# Altium schematic sheet lv_mcu_ios_100.SchDoc(lv_mcu_ios_100)
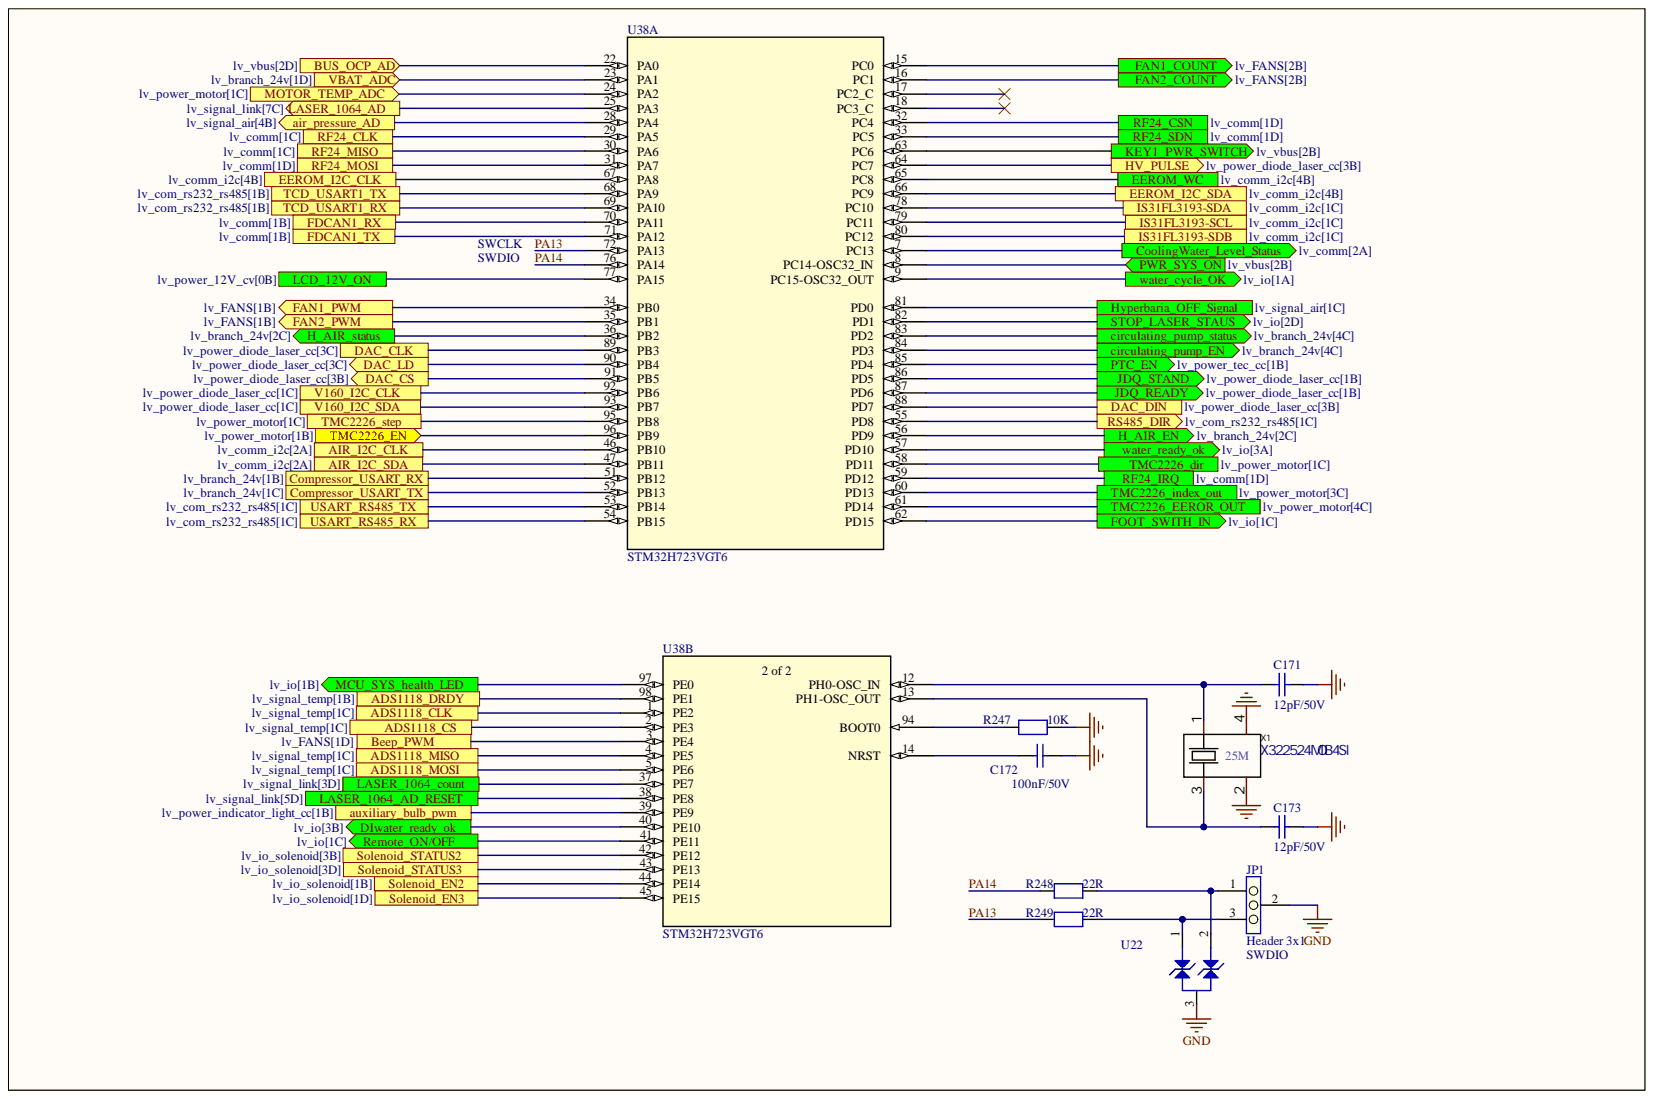
[diagram p0: lv_mcu_ios_100.SchDoc]

## Parts
C171  12pF/50V  [C0402]
C172  100nF/50V  [C0402]
C173  12pF/50V  [C0402]
JP1  value Header 3x1  [CON_SAMTEC_TSW-103-07-F-S]  (hidden comment: Jumper_Header 3x1)
R247  10K  [R0402]
R248  22R  [R0402]
R249  22R  [R0402]
U22  ESDCAN03-2BWY  [DIO_SOT323]  (value not drawn)
U38  STM32H723VGT6  [LQFP100_STM-L]  (parts A,B)
X1  X322524MOB4SI  [CRYSTAL-SMD_4P-L3.2-W2.5-BL]

## Ports
ADS1118_CLK, ADS1118_CS, ADS1118_DRDY, ADS1118_MISO, ADS1118_MOSI, AIR_I2C_CLK, AIR_I2C_SDA, air_pressure_AD, auxiliary_bulb_pwm, Beep_PWM, BUS_OCP_AD, circulating_pump_EN, circulating_pump_status, Compressor_USART_RX, Compressor_USART_TX, CoolingWater_Level_Status, DAC_CLK, DAC_CS, DAC_DIN, DAC_LD, DIwater_ready_ok, EEROM_I2C_CLK, EEROM_I2C_SDA, EEROM_WC, FAN1_COUNT, FAN1_PWM, FAN2_COUNT, FAN2_PWM, FDCAN1_RX, FDCAN1_TX, FOOT_SWITH_IN, H_AIR_EN, H_AIR_status, HV_PULSE, Hyperbaria_OFF_Signal, IS31FL3193-SCL, IS31FL3193-SDA, IS31FL3193-SDB, JDQ_READY, JDQ_STAND, KEY1_PWR_SWITCH, LASER_1064_AD, LASER_1064_AD_RESET, LASER_1064_count, LCD_12V_ON, MCU_SYS_health_LED, MOTOR_TEMP_ADC, PTC_EN, PWR_SYS_ON, Remote_ON/OFF, RF24_CLK, RF24_CSN, RF24_IRQ, RF24_MISO, RF24_MOSI, RF24_SDN, RS485_DIR, Solenoid_EN2, Solenoid_EN3, Solenoid_STATUS2, Solenoid_STATUS3, STOP_LASER_STAUS, TCD_USART1_RX, TCD_USART1_TX, TMC2226_dir, TMC2226_EEROR_OUT, TMC2226_EN, TMC2226_index_out, TMC2226_step, USART_RS485_RX, USART_RS485_TX, V160_I2C_CLK, V160_I2C_SDA, VBAT_ADC, water_cycle_OK, water_ready_ok

## Nets
GND: C171.1 C172.1 C173.1 JP1.2 R247.2 U22.3 X1.2 X1.4
ADS1118_CLK: U38.1(PE2) ; port ADS1118_CLK
ADS1118_CS: U38.2(PE3) ; port ADS1118_CS
ADS1118_DRDY: U38.98(PE1) ; port ADS1118_DRDY
ADS1118_MISO: U38.4(PE5) ; port ADS1118_MISO
ADS1118_MOSI: U38.5(PE6) ; port ADS1118_MOSI
AIR_I2C_CLK: U38.46(PB10) ; port AIR_I2C_CLK
AIR_I2C_SDA: U38.47(PB11) ; port AIR_I2C_SDA
air_pressure_AD: U38.28(PA4) ; port air_pressure_AD
auxiliary_bulb_pwm: U38.39(PE9) ; port auxiliary_bulb_pwm
Beep_PWM: U38.3(PE4) ; port Beep_PWM
BUS_OCP_AD: U38.22(PA0) ; port BUS_OCP_AD
circulating_pump_EN: U38.84(PD3) ; port circulating_pump_EN
circulating_pump_status: U38.83(PD2) ; port circulating_pump_status
Compressor_USART_RX: U38.51(PB12) ; port Compressor_USART_RX
Compressor_USART_TX: U38.52(PB13) ; port Compressor_USART_TX
CoolingWater_Level_Status: U38.7(PC13) ; port CoolingWater_Level_Status
DAC_CLK: U38.89(PB3) ; port DAC_CLK
DAC_CS: U38.91(PB5) ; port DAC_CS
DAC_DIN: U38.88(PD7) ; port DAC_DIN
DAC_LD: U38.90(PB4) ; port DAC_LD
DIwater_ready_ok: U38.40(PE10) ; port DIwater_ready_ok
EEROM_I2C_CLK: U38.67(PA8) ; port EEROM_I2C_CLK
EEROM_I2C_SDA: U38.66(PC9) ; port EEROM_I2C_SDA
EEROM_WC: U38.65(PC8) ; port EEROM_WC
FAN1_COUNT: U38.15(PC0) ; port FAN1_COUNT
FAN1_PWM: U38.34(PB0) ; port FAN1_PWM
FAN2_COUNT: U38.16(PC1) ; port FAN2_COUNT
FAN2_PWM: U38.35(PB1) ; port FAN2_PWM
FDCAN1_RX: U38.70(PA11) ; port FDCAN1_RX
FDCAN1_TX: U38.71(PA12) ; port FDCAN1_TX
FOOT_SWITH_IN: U38.62(PD15) ; port FOOT_SWITH_IN
H_AIR_EN: U38.56(PD9) ; port H_AIR_EN
H_AIR_status: U38.36(PB2) ; port H_AIR_status
HV_PULSE: U38.64(PC7) ; port HV_PULSE
Hyperbaria_OFF_Signal: U38.81(PD0) ; port Hyperbaria_OFF_Signal
IS31FL3193-SCL: U38.79(PC11) ; port IS31FL3193-SCL
IS31FL3193-SDA: U38.78(PC10) ; port IS31FL3193-SDA
IS31FL3193-SDB: U38.80(PC12) ; port IS31FL3193-SDB
JDQ_READY: U38.87(PD6) ; port JDQ_READY
JDQ_STAND: U38.86(PD5) ; port JDQ_STAND
KEY1_PWR_SWITCH: U38.63(PC6) ; port KEY1_PWR_SWITCH
LASER_1064_AD: U38.25(PA3) ; port LASER_1064_AD
LASER_1064_AD_RESET: U38.38(PE8) ; port LASER_1064_AD_RESET
LASER_1064_count: U38.37(PE7) ; port LASER_1064_count
LCD_12V_ON: U38.77(PA15) ; port LCD_12V_ON
MCU_SYS_health_LED: U38.97(PE0) ; port MCU_SYS_health_LED
MOTOR_TEMP_ADC: U38.24(PA2) ; port MOTOR_TEMP_ADC
PA13: R249.1 U38.72(PA13)
PA14: R248.1 U38.76(PA14)
PTC_EN: U38.85(PD4) ; port PTC_EN
PWR_SYS_ON: U38.8(PC14-OSC32_IN) ; port PWR_SYS_ON
Remote_ON/OFF: U38.41(PE11) ; port Remote_ON/OFF
RF24_CLK: U38.29(PA5) ; port RF24_CLK
RF24_CSN: U38.32(PC4) ; port RF24_CSN
RF24_IRQ: U38.59(PD12) ; port RF24_IRQ
RF24_MISO: U38.30(PA6) ; port RF24_MISO
RF24_MOSI: U38.31(PA7) ; port RF24_MOSI
RF24_SDN: U38.33(PC5) ; port RF24_SDN
RS485_DIR: U38.55(PD8) ; port RS485_DIR
Solenoid_EN2: U38.44(PE14) ; port Solenoid_EN2
Solenoid_EN3: U38.45(PE15) ; port Solenoid_EN3
Solenoid_STATUS2: U38.42(PE12) ; port Solenoid_STATUS2
Solenoid_STATUS3: U38.43(PE13) ; port Solenoid_STATUS3
STOP_LASER_STAUS: U38.82(PD1) ; port STOP_LASER_STAUS
TCD_USART1_RX: U38.69(PA10) ; port TCD_USART1_RX
TCD_USART1_TX: U38.68(PA9) ; port TCD_USART1_TX
TMC2226_dir: U38.58(PD11) ; port TMC2226_dir
TMC2226_EEROR_OUT: U38.61(PD14) ; port TMC2226_EEROR_OUT
TMC2226_EN: U38.96(PB9) ; port TMC2226_EN
TMC2226_index_out: U38.60(PD13) ; port TMC2226_index_out
TMC2226_step: U38.95(PB8) ; port TMC2226_step
USART_RS485_RX: U38.54(PB15) ; port USART_RS485_RX
USART_RS485_TX: U38.53(PB14) ; port USART_RS485_TX
V160_I2C_CLK: U38.92(PB6) ; port V160_I2C_CLK
V160_I2C_SDA: U38.93(PB7) ; port V160_I2C_SDA
VBAT_ADC: U38.23(PA1) ; port VBAT_ADC
water_cycle_OK: U38.9(PC15-OSC32_OUT) ; port water_cycle_OK
water_ready_ok: U38.57(PD10) ; port water_ready_ok
NetC171_2: C171.2 U38.12(PH0-OSC_IN) X1.1
NetC172_2: C172.2 U38.14(NRST)
NetC173_2: C173.2 U38.13(PH1-OSC_OUT) X1.3
NetJP1_1: JP1.1 R248.2 U22.2
NetJP1_3: JP1.3 R249.2 U22.1
NetR247_1: R247.1 U38.94(BOOT0)

Not connected: U38 17(PC2_C) 18(PC3_C)

## Library data of the parts
C171 C173 = MCD-00674: 50V,5%,C0G; Manufacturer: muRata; Manufacturer_Part_Number: GRM1555C1H120JA01D; Database Table Name: MCD_PARTS$; Component Kind: Standard; Library Name: MCD_PARTS_database.DbLib
  C173: ComponentLink1Description: Datasheet; ComponentLink1URL: http://tunmcdsvn.tun.st.com/Component_Datasheet_Library/Trunk/Capacitors/Yageo_Capacitor_NP0_16V-to-50V
C172 = MCD-00102: X7R, 10%; Manufacturer: YAGEO; Manufacturer_Part_Number: GRM155R71H104KE14D; Database Table Name: MCD_PARTS$; Component Kind: Standard; Library Name: MCD_PARTS_database.DbLib
JP1 = MCD-00111: Header, 3x1, 2,54mm, VR, TH, Height 5.84mm/2.54mm; Manufacturer: SAMTEC; Manufacturer_Part_Number: TSW-103-07-F-S; Part_Type: Connector; Initial_Board_Reference: MB1262; Item Type: Item; Path: ws/MCD_ApplicationBoards/Lists/MCDPARTSdatabase; Provided_By: SUBCO; Component Kind: Standard; ComponentLink1Description: Datasheet; ComponentLink1URL: http://tunmcdsvn.tun.st.com/Component_Datasheet_Library/Trunk/Connectors/Samtec_TSW-HTSW-series; Internal_Part_Number: MCD-00111; Library Name: MB1397.IntLib; Status: Released
R247 = RES: 1%; Component Kind: Standard; Library Name: HiPin_Library_AD.IntLib; Value: 10K
R248 R249 = RES: 1%; Value: 10K; Component Kind: Standard; Library Name: HiPin_Library_AD.IntLib
U22 = MCD-01228: 极性双向,反向截止电压(Vrwm)24V,钳位电压41V  ,峰值脉冲电流(Ipp)3.7A@8/20us; Manufacturer: STMICROELECTRONICS; Database Table Name: MCD_PARTS$; Component Kind: Standard; Library Name: MCD_PARTS_database.DbLib; Manufacturer_Part_Number: ESDCAN03-2BWY
U38 = STM32H723VGT6: No Description Available; Mfr_Name: STMicroelectronics; Component Kind: Standard; Library Name: HiPin_Library_AD.IntLib; RefDes: RefDes; Type: Type
X1 = X322524MOB4SI: 24MHz 10ppm 12pF; Manufacturer: YXC(扬兴晶振); Add into BOM: yes; Crystal Type: 贴片无源晶振; Frequency Stability: ±30ppm; Component Kind: Standard; Convert to PCB: yes; Datasheet: https://atta.szlcsc.com/upload/public/pdf/source/20210329/C112971_1F611BDC486E193C1706F6D8EB5AA189.pdf; Device: X322524MOB4SI; Frequency Tolerance: ±10ppm; JLCPCB Part Class: Extended Part; LCSC Part Name: 24MHz ±10ppm 12pF YSX321SL; Library Name: HiPin_Library_AD.IntLib; Load Capacitance: 12pF; Manufacturer Part: X322524MOB4SI; Name: 24MHz; Operating Temperature: -40℃~+85℃; PCB3D: OSC-SMD_4P-L3.2-W2.5-H1.0; Supplier: LCSC; Supplier Footprint: SMD3225-4P; Supplier Part: C70590; Symbol: X322524MOB4SI; Value: 24MHz
